# Altium schematic sheet Carte CMODA7.SchDoc
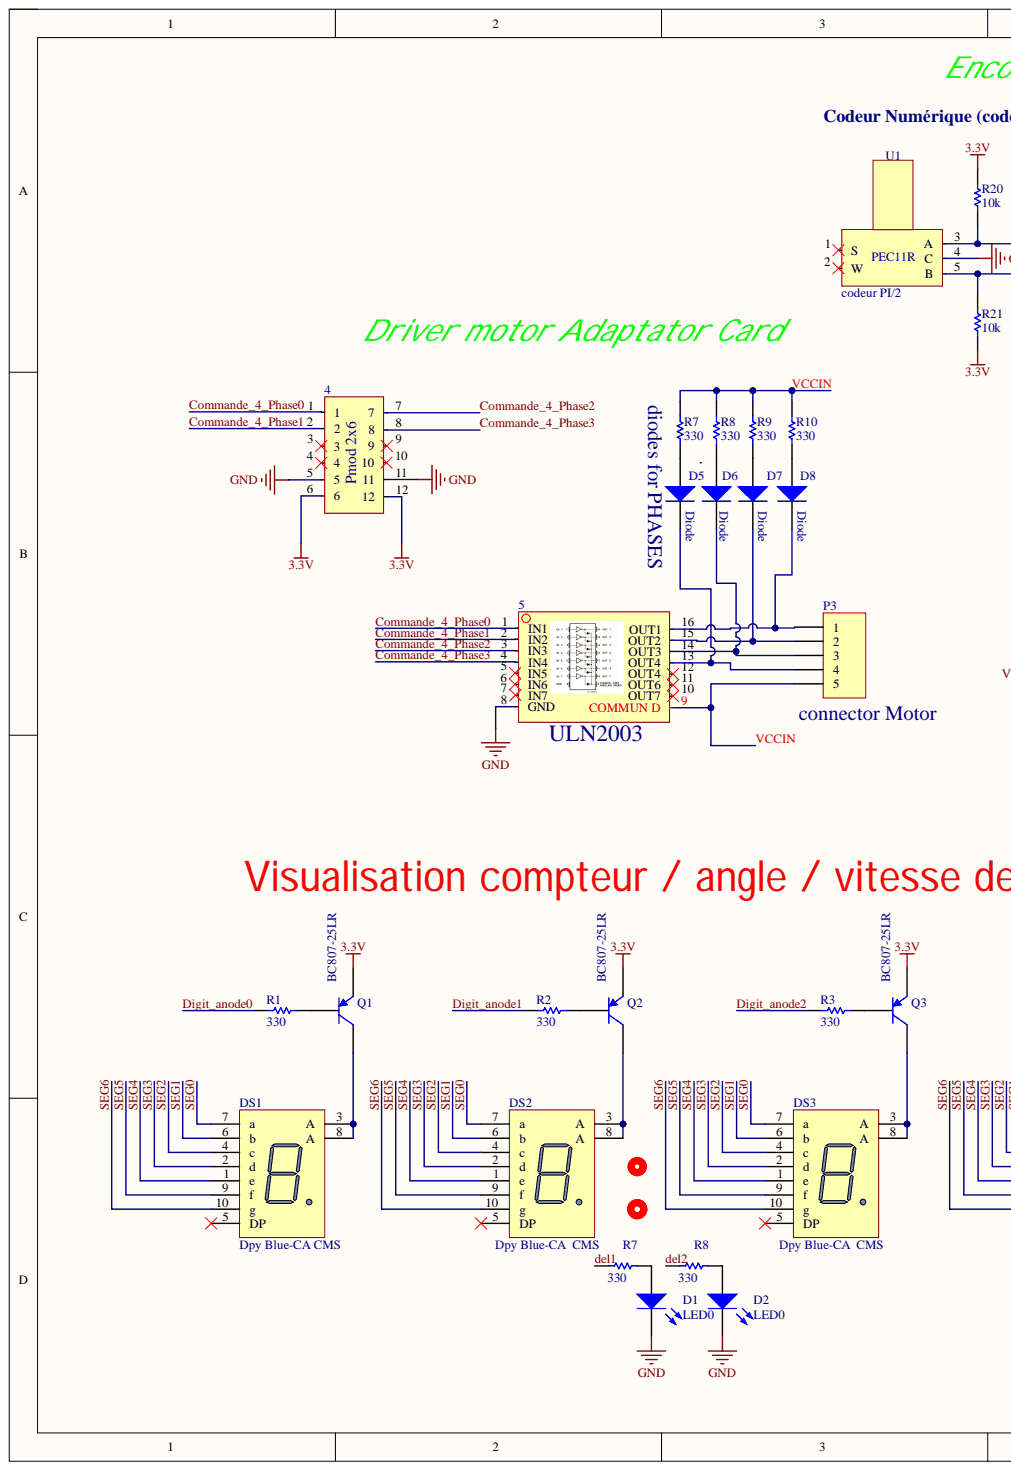
[diagram p0: Carte CMODA7.SchDoc, left]
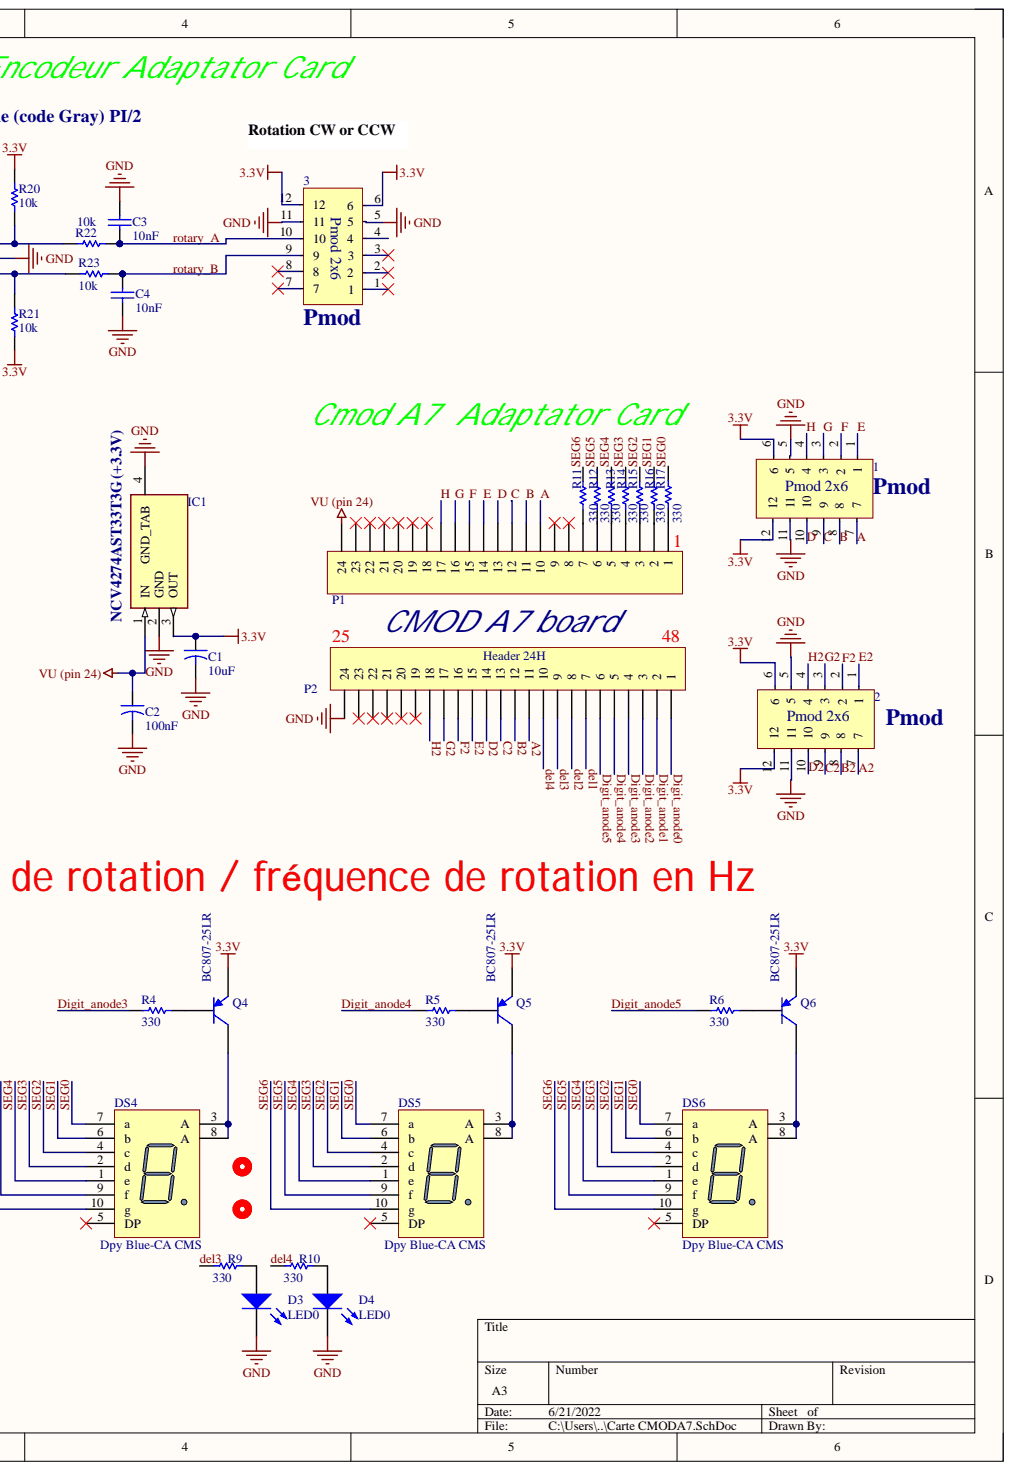
[diagram p1: Carte CMODA7.SchDoc, right]

## Parts
1  Pmod
2  Pmod
3  Pmod
4  (no value)  [HDR2X6H]
5  ULN2003  [SOL-16_N]
C1  10uF  [CAPC1608X90N]
C2  100nF  [CAPC1608X90N]
C3  value 10nF  [1206CS102XJLB]  (hidden comment: Cap)
C4  value 10nF  [1206CS102XJLB]  (hidden comment: Cap)
D1  LED0  [RESC1608X55N]
D2  LED0  [RESC1608X55N]
D3  LED0  [RESC1608X55N]
D4  LED0  [LED-0]
D5  Diode  [C1210]
D6  Diode  [C1210]
D7  Diode  [C1210]
D8  Diode  [C1210]
DS1  Dpy Blue-CA CMS  [VDMG10C0_v2]
DS2  Dpy Blue-CA  CMS  [VDMG10C0_v2]
DS3  Dpy Blue-CA  CMS  [VDMG10C0_v2]
DS4  Dpy Blue-CA CMS  [VDMG10C0_v2]
DS5  Dpy Blue-CA CMS  [VDMG10C0_v2]
DS6  Dpy Blue-CA CMS  [VDMG10C0_v2]
IC1  NCV4274AST33T3G (+3.3V)  [SOT96P237X111-3N]
P1  (no value)  [HDR1X24H]
P2  Header 24H  [HDR1X24H]
P3  connector Motor  [HDR1X5H]
Q1  BC807-25LR  [SOT65P210X110-3N]
Q2  BC807-25LR  [SOT65P210X110-3N]
Q3  BC807-25LR  [SOT65P210X110-3N]
Q4  BC807-25LR  [SOT65P210X110-3N]
Q5  BC807-25LR  [SOT65P210X110-3N]
Q6  BC807-25LR  [SOT65P210X110-3N]
R1  330  [RESC1608X55N]
R2  330  [RESC1608X55N]
R3  330  [RESC1608X55N]
R4  330  [RESC1608X55N]
R5  330  [RESC1608X55N]
R6  330  [RESC1608X55N]
R7  value 330  [C1206]  (hidden comment: Res1)
R7  330  [RESC1608X55N]
R8  value 330  [C1206]  (hidden comment: Res1)
R8  330  [RESC1608X55N]
R9  value 330  [C1206]  (hidden comment: Res1)
R9  330  [RESC1608X55N]
R10  value 330  [C1206]  (hidden comment: Res1)
R10  330  [RESC1608X55N]
R11  330  [RESC1608X55N]
R12  330  [RESC1608X55N]
R13  330  [RESC1608X55N]
R14  330  [RESC1608X55N]
R15  330  [RESC1608X55N]
R16  330  [RESC1608X55N]
R17  330  [RESC1608X55N]
R20  value 10k  [AXIAL-0.3]  (hidden comment: Res1)
R21  value 10k  [AXIAL-0.3]  (hidden comment: Res1)
R22  value 10k  [AXIAL-0.3]  (hidden comment: Res1)
R23  value 10k  [CAPC3216L]  (hidden comment: Res1)
U1  codeur PI/2  [PEC11R]

## Nets
3.3V: 1.6 1.12 2.6 2.12 3.6 3.12 4.6 4.12 C1.1 IC1.3(OUT) Q1.1 Q2.1 Q3.1 Q4.1 Q5.1 Q6.1 R20.1 R21.2
GND: 1.5 1.11 2.5 2.11 3.5 3.11 4.5 4.11 5.8(GND) C1.2 C2.2 C3.2 C4.1 D1.2 D2.2 D3.2 D4.2 IC1.2(GND) IC1.4(GND_TAB) P2.24 U1.4(C)
VU (pin 24): C2.1 IC1.1(IN) P1.24
A: 1.7 P1.10
A2: 2.7 P2.11
B: 1.8 P1.11
B2: 2.8 P2.12
C: 1.9 P1.12
C2: 2.9 P2.13
Commande_4_Phase0: 4.1 5.1(IN1)
Commande_4_Phase1: 4.2 5.2(IN2)
Commande_4_Phase2: 4.7 5.3(IN3)
Commande_4_Phase3: 4.8 5.4(IN4)
D: 1.10 P1.13
D2: 2.10 P2.14
del1: P2.7 R7.2
del2: P2.8 R8.2
del3: P2.9 R9.2
del4: P2.10 R10.2
Digit_anode0: P2.1 R1.1
Digit_anode1: P2.2 R2.1
Digit_anode2: P2.3 R3.1
Digit_anode3: P2.4 R4.1
Digit_anode4: P2.5 R5.1
Digit_anode5: P2.6 R6.1
E: 1.1 P1.14
E2: 2.1 P2.15
F: 1.2 P1.15
F2: 2.2 P2.16
G: 1.3 P1.16
G2: 2.3 P2.17
H: 1.4 P1.17
H2: 2.4 P2.18
SEG0: DS1.7(a) DS2.7(a) DS3.7(a) DS4.7(a) DS5.7(a) DS6.7(a)
SEG1: DS1.6(b) DS2.6(b) DS3.6(b) DS4.6(b) DS5.6(b) DS6.6(b) R16.1
SEG2: DS1.4(c) DS2.4(c) DS3.4(c) DS4.4(c) DS5.4(c) DS6.4(c) R15.1
SEG3: DS1.2(d) DS2.2(d) DS3.2(d) DS4.2(d) DS5.2(d) DS6.2(d) R14.1
SEG4: DS1.1(e) DS2.1(e) DS3.1(e) DS4.1(e) DS5.1(e) DS6.1(e) R13.1
SEG5: DS1.9(f) DS2.9(f) DS3.9(f) DS4.9(f) DS5.9(f) DS6.9(f) R12.1
SEG6: DS1.10(g) DS2.10(g) DS3.10(g) DS4.10(g) DS5.10(g) DS6.10(g) R11.1
VCCIN: 5.9(COMMUN_D) P3.5 R7.1 R8.1 R9.1 R10.1
Net3_9: 3.9 C4.2 R23.2
Net3_10: 3.10 C3.1 R22.2
Net5_13: 5.13(OUT4) D5.2 P3.4
Net5_14: 5.14(OUT3) D6.2 P3.3
Net5_15: 5.15(OUT2) D7.2 P3.2
Net5_16: 5.16(OUT1) D8.2 P3.1
NetD1_1: D1.1 R7.1
NetD2_1: D2.1 R8.1
NetD3_1: D3.1 R9.1
NetD4_1: D4.1 R10.1
NetD5_1: D5.1 R7.2
NetD6_1: D6.1 R8.2
NetD7_1: D7.1 R9.2
NetD8_1: D8.1 R10.2
NetDS1_3: DS1.3(A) DS1.8(A) Q1.3
NetDS2_3: DS2.3(A) DS2.8(A) Q2.3
NetDS3_3: DS3.3(A) DS3.8(A) Q3.3
NetDS4_3: DS4.3(A) DS4.8(A) Q4.3
NetDS5_3: DS5.3(A) DS5.8(A) Q5.3
NetDS6_3: DS6.3(A) DS6.8(A) Q6.3
NetP1_1: P1.1 R17.2
NetQ1_2: Q1.2 R1.2
NetQ2_2: Q2.2 R2.2
NetQ3_2: Q3.2 R3.2
NetQ4_2: Q4.2 R4.2
NetQ5_2: Q5.2 R5.2
NetQ6_2: Q6.2 R6.2
NetR20_2: R20.2 R22.1 U1.3(A)
NetR21_1: R21.1 R23.1 U1.5(B)
rotary_A:
rotary_B:

Not connected: 3 1 2 3 4 7 8; 4 3 4 9 10; 5 5(IN5) 6(IN6) 7(IN7) 10(OUT7) 11(OUT6) 12(OUT4); DS1 5(DP); DS2 5(DP); DS3 5(DP); DS4 5(DP); DS5 5(DP); DS6 5(DP); P1 2 3 4 5 6 7 8 9 18 19 20 21 22 23; P2 19 20 21 22 23; R11 2; R12 2; R13 2; R14 2; R15 2; R16 2; R17 1; U1 1(S) 2(W)

## Library data of the parts
4 = Pmod: Component Kind: Standard; Library Name: FABRICE.SCHLIB
C1 C2 = Cap2: Capacitor; Value: 100pF; Component Kind: Standard; Library Name: Miscellaneous Devices.IntLib; PackageReference: CAPR5-4X5; Published: 23-Sep-2002; Signal Integrity: Cap; Simulation: CAP; Publisher: Altium Limited
C3 C4 = Cap: Capacitor; Component Kind: Standard; LatestRevisionDate: 17-Jul-2002; LatestRevisionNote: Re-released for DXP Platform.; Library Name: Miscellaneous Devices.IntLib; PackageDescription: Radial Cap, Thru-Hole; 2 Leads; 0.3 in Pin Spacing; PackageReference: RAD-0.3; Published: 8-Jun-2000; Signal Integrity: Cap; Simulation: CAP; Publisher: Altium Limited
D1-D4 = LED0: Typical INFRARED GaAs LED; Component Kind: Standard; LatestRevisionDate: 08-Jul-2005; LatestRevisionNote: Mech Layer 1 removed.; Library Name: Miscellaneous Devices.IntLib; PackageDescription: LED; 2 Leads; PackageReference: LED-0; Published: 8-Jun-2000; Simulation: LED0; Publisher: Altium Limited
D5-D8 = Diode: Default Diode; Code_JEDEC: DO-214-AB; Component Kind: Standard; LatestRevisionDate: 17-Jul-2002; LatestRevisionNote: Re-released for DXP Platform.; Library Name: Miscellaneous Devices.IntLib; PackageDescription: DO-214-AB/SMC; 2 C-Bend Leads; Body 7.9 x 5.9 mm, inc. leads (LxW); PackageReference: SMC; PackageVersion: Sep-1996; Published: 8-Jun-2000; Signal Integrity: Diode; Simulation: DIODE; Publisher: Altium Limited
DS1-DS6 = Dpy Blue-CA: 14.2 mm General Purpose Blue 7-Segment Display: CA, RH DP, Gray Surface; MinimumIntensity: 2020 ucd; Note: IF = 10 mA; Colour: Blue; Component Kind: Standard; ComponentLink1Description: Manufacturer Link; ComponentLink1URL: http://www.agilent.com/; DatasheetVersion: 24-Sep-2002; LatestRevisionDate: 17-Dec-2003; LatestRevisionNote: Schematic revised, PCB Footprint replaced.; Library Name: Miscellaneous Devices.IntLib; PackageDescription: LED, 7-Segment Display, 14.2 mm, RHD; 10 Leads; Row Space 15.24 mm; Pitch 2.54 mm; PackageReference: H; PackageVersion: 24-Sep-2002; Published: 8-Jun-2000; Simulation: HDSP_501B; Publisher: Altium Limited
IC1 = NCV4274AST33T3G: Integrated Circuit; Manufacturer_Name: ON Semiconductor; Allied_Number: 70341100; Allied Price/Stock: http://www.alliedelec.com/on-semiconductor-ncv4274ast33t3g/70341100/; Component Kind: Standard; Datasheet Link: http://www.onsemi.com/pub/Collateral/NCV4274-D.PDF; Height: 1.75mm; Manufacturer_Part_Number: NCV4274AST33T3G; Mouser Part Number: 863-NCV4274AST33T3G; Mouser Price/Stock: https://www.mouser.com/Search/Refine.aspx?Keyword=863-NCV4274AST33T3G; RS Part Number: 7577190P; RS Price/Stock: http://uk.rs-online.com/web/p/products/7577190P
P1 P2 = Header 24H: Header, 24-Pin, Right Angle; Component Kind: Standard; LatestRevisionDate: 17-Jul-2002; LatestRevisionNote: Re-released for DXP Platform.; Library Name: Miscellaneous Connectors.IntLib; Signal Integrity: Connector; Publisher: Altium Limited
P3 = Header 5H: Header, 5-Pin, Right Angle; Component Kind: Standard; LatestRevisionDate: 17-Jul-2002; LatestRevisionNote: Re-released for DXP Platform.; Library Name: Miscellaneous Connectors.IntLib; Signal Integrity: Connector; Publisher: Altium Limited
Q1-Q6 = 2N3906: PNP General Purpose Amplifier; Component Kind: Standard; ComponentLink1Description: Manufacturer Link; ComponentLink1URL: http://www.fairchildsemi.com/; ComponentLink2Description: Datasheet; ComponentLink2URL: http://www.fairchildsemi.com/ds/2N%2F2N3906.pdf; DatasheetVersion: 1997; LatestRevisionDate: 15-Jan-2003; LatestRevisionNote: PCB Footprint 'BCY-W3/D4.7' replaced; Library Name: Miscellaneous Devices.IntLib; PackageDescription: TO, Flat Index; 3 In-Line, Axial Leads; Body Dia. 4.5mm; Leads 0.51 x 0.48 mm (max); PackageReference: TO-92A; PackageVersion: Sep-1998; Published: 8-Jun-2000; Signal Integrity: 2N3906; Simulation: 2N3906; Publisher: Altium Limited
R1-R7 R7 R8 R8 R9 R9 R10 R10-R17 R20-R23 = Res1: Resistor; Component Kind: Standard; LatestRevisionDate: 17-Jul-2002; LatestRevisionNote: Re-released for DXP Platform.; Library Name: Miscellaneous Devices.IntLib; PackageDescription: Axial Device, Thru-Hole; 2 Leads; 0.3 in Pin Spacing; PackageReference: AXIAL-0.3; Published: 8-Jun-2000; Signal Integrity: Res; Simulation: RESISTOR; Publisher: Altium Limited
U1 = codeur PI/2: 12 mm Incremental Encodeur; Component Kind: Standard; Library Name: FABRICE.SCHLIB
1-3 = Pmod: Component Kind: Standard; Library Name: FABRICE.SCHLIB
5 = ULN2003: Component Kind: Standard; Library Name: FABRICE.SCHLIB
